# Altium schematic sheet MPD_MINI_DEMO_B2.SchDoc(MPD_MINI_DEMO_B2)
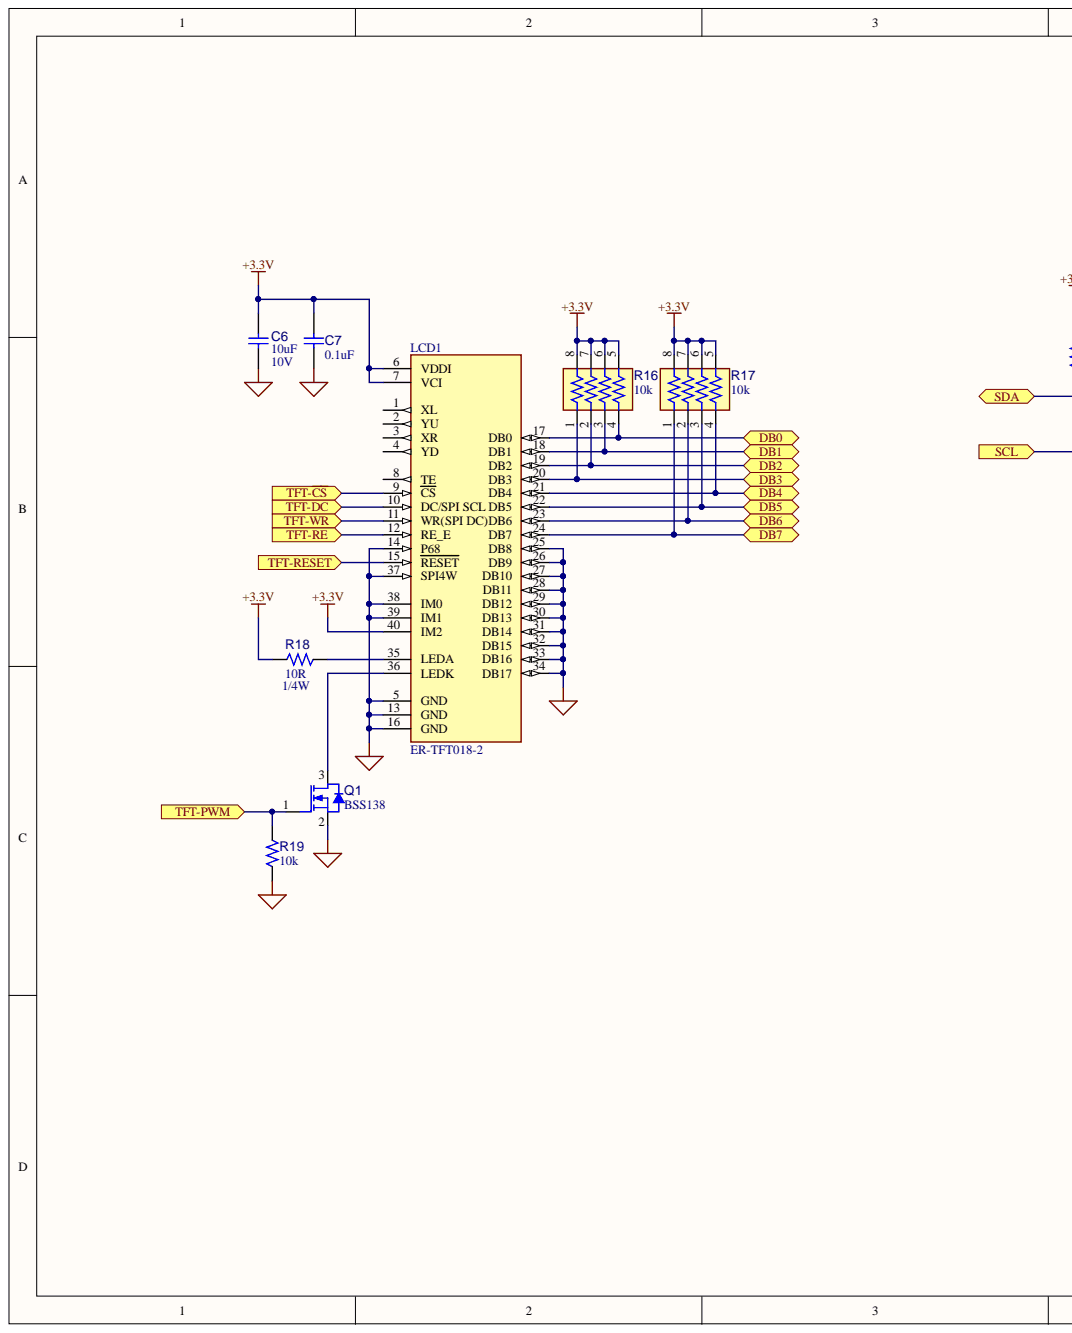
[diagram p0: MPD_MINI_DEMO_B2.SchDoc, left]
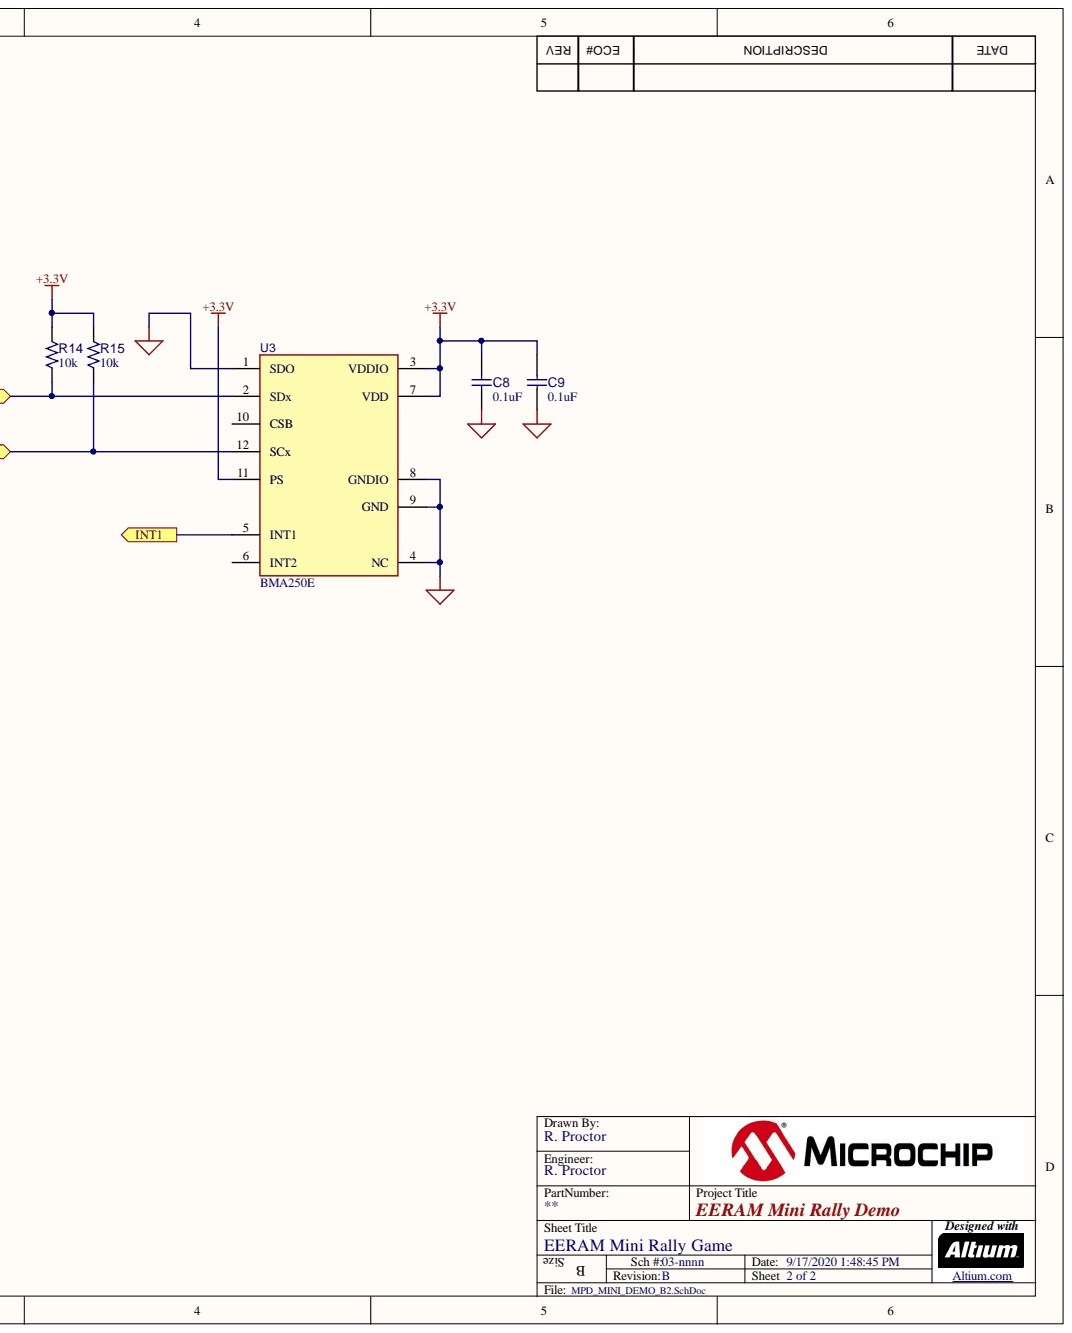
[diagram p1: MPD_MINI_DEMO_B2.SchDoc, right]

## Parts
C6  value 10uF  Voltage: 10V  [CAP_C_0603]  (hidden comment: Capacitor NP)
C7  value 0.1uF  [CAP_C_0603]  (hidden comment: Capacitor NP)
C8  value 0.1uF  [CAP_C_0603]  (hidden comment: Capacitor NP)
C9  value 0.1uF  [CAP_C_0603]  (hidden comment: Capacitor NP)
LCD1  value ER-TFT018-2  [DISPLAY_LCD_ER-TFT018-2]  (hidden comment: DISPLAY LCD ER-TFT018-2)
Q1  value BSS138  [SOT-23-3]  (hidden comment: Transistor MOS-N GSD)
R14  value 10k  [RES_C_0603]  (hidden comment: Resistor)
R15  value 10k  [RES_C_0603]  (hidden comment: Resistor)
R16  value 10k  [RES_C_CAV_0402X4]  (hidden comment: Resistor Network 4-Ch)
R17  value 10k  [RES_C_CAV_0402X4]  (hidden comment: Resistor Network 4-Ch)
R18  value 10R  Power: 1/4W  [RES_C_1206]  (hidden comment: Resistor)
R19  value 10k  [RES_C_0603]  (hidden comment: Resistor)
U3  BMA250E  [LGA-12_2x2]

## Ports
DB0, DB1, DB2, DB3, DB4, DB5, DB6, DB7, INT1, SCL, SDA, TFT-DC, TFT-PWM, TFT-RE, TFT-RESET, TFT-WR, TFT-~{CS}

## Nets
+3.3V: C6.2 C7.1 C8.1 C9.1 LCD1.6(VDDI) LCD1.7(VCI) LCD1.40(IM2) R14.1 R15.1 R16.5 R16.6 R16.7 R16.8 R17.5 R17.6 R17.7 R17.8 R18.1 U3.3(VDDIO) U3.7(VDD) U3.11(PS)
GND: C6.1 C7.2 C8.2 C9.2 LCD1.5(GND) LCD1.13(GND) LCD1.14(P68) LCD1.16(GND) LCD1.25(DB8) LCD1.26(DB9) LCD1.27(DB10) LCD1.28(DB11) LCD1.29(DB12) LCD1.30(DB13) LCD1.31(DB14) LCD1.32(DB15) LCD1.33(DB16) LCD1.34(DB17) LCD1.37(SPI4W) LCD1.38(IM0) LCD1.39(IM1) Q1.2 R19.2 U3.1(SDO) U3.4(NC) U3.8(GNDIO) U3.9(GND)
DB0: LCD1.17(DB0) R16.4 ; port DB0
DB1: LCD1.18(DB1) R16.3 ; port DB1
DB2: LCD1.19(DB2) R16.2 ; port DB2
DB3: LCD1.20(DB3) R16.1 ; port DB3
DB4: LCD1.21(DB4) R17.4 ; port DB4
DB5: LCD1.22(SCL_DB5) R17.3 ; port DB5
DB6: LCD1.23(DC)_DB6) R17.2 ; port DB6
DB7: LCD1.24(DB7) R17.1 ; port DB7
INT1: U3.5(INT1) ; port INT1
SCL: R15.2 U3.12(SCx) ; port SCL
SDA: R14.2 U3.2(SDx) ; port SDA
TFT-~{CS}: LCD1.9(CS) ; port TFT-~{CS}
TFT-DC: LCD1.10 ; port TFT-DC
TFT-PWM: Q1.1 R19.1 ; port TFT-PWM
TFT-RE: LCD1.12(RE_E) ; port TFT-RE
TFT-RESET: LCD1.15(RESET) ; port TFT-RESET
TFT-WR: LCD1.11 ; port TFT-WR
NetLCD1_35: LCD1.35(LEDA) R18.2
NetLCD1_36: LCD1.36(LEDK) Q1.3

Not connected: LCD1 1(XL) 2(YU) 3(XR) 4(YD) 8(TE); U3 6(INT2) 10(CSB)

## Library data of the parts
C6 = CAP0542: Capacitor; Manufacturer Part Number 1: ECJ-1VB1A106M; Manufacturer 1: Panasonic; Type: Ceramic; Tolerance: 20%; Temperature Coeffcient: X5R; Populated: Yes; Dev Tools Status: Production; Description_: CAP CER 10uF 10V 20% X5R SMD 0603; Symbol: Capacitor NP; Supplier Part Number 2: 445-6853-1-ND; Supplier Part Number 1: PCC2479CT-ND; Supplier 2: Digi-Key; Supplier 1: Digi-Key; Status: MCL Design; Revision: 2; Quantity Override: 1; Package: 0603; Operating Temperature: -55C to +85C; MCHP-ID: CAP0542; Manufacturer Part Number 2: C1608X5R1A106M; Manufacturer 2: TDK Corporation; Library Name: MCHP-CDB.DbLib; Database Table Name: Capacitor; ComponentLink1URL: https://chn-vm-apps.microchip.com/mchprepo/parts/Datasheets/ECJ__General_.pdf; ComponentLink1Description: Datasheet; Component Kind: Standard; Category: Capacitor
C7-C9 = CAP1047: Capacitor; Manufacturer Part Number 1: C1608X7R1H104M; Manufacturer 1: TDK; Voltage: 50V; Type: Ceramic; Tolerance: 20%; Temperature Coeffcient: X7R; Populated: Yes; Owner: Leah Whitaker; Dev Tools Status: Production; Description_: CAP CER 0.1uF 50V 20% X7R SMD 0603; Symbol: Capacitor NP; Supplier Part Number 2: C1608X7R1H104M080AA; Supplier Part Number 1: 445-5098-1-ND; Supplier 2: TDK; Supplier 1: Digi-Key; Status: MCL Design; Revision: 1; Quantity Override: 1; Package: 0603; Operating Temperature: -55C to +125C; MCHP-ID: CAP1047; Manufacturer Part Number 2: C1608X7R1H104M080AA; Manufacturer 2: TDK; Library Name: MCHP-CDB.DbLib; Database Table Name: Capacitor; ComponentLink1URL: https://chn-vm-apps.microchip.com/mchprepo/parts/Datasheets/general_B11.pdf; ComponentLink1Description: Datasheet; Component Kind: Standard; Category: Capacitor
LCD1 = DISPLAY0014: Manufacturer 1: EastRising Technology Co., Limited; Populated: YES; Owner: Mark Loper; Dev Tools Status: Design; Description_: DISPLAY LCD TFT 128x160 GRAPHIC ILI9163C ER-TFT018-2; Type: LCD; Symbol: DISPLAY LCD ER-TFT018-2; Supplier Part Number 1: ER-TFT018-2; Supplier 1: BUYDISPLAY.COM; Status: MCL Design; Revision: A; Quantity Override: 1; MCHP-ID: DISPLAY0014; Manufacturer Part Number 1: ER-TFT018-2; Library Name: MCHP-CDB.DbLib; Database Table Name: Display; ComponentLink1URL: https://chn-vm-apps.microchip.com/mchprepo/parts/Datasheets/ER-TFT0018-2_Datasheet.pdf; ComponentLink1Description: Datasheet; Component Kind: Standard; Category: Display
Q1 = TRA1102: Transistor MOS; Manufacturer Part Number 1: 2N7002-7-F; Manufacturer 1: Diodes Inc; Type: FET; Power: 370mW; Populated: YES; Owner: Adrian M (M91404); Gate to Source Voltage: 20V; Drain to Source Voltage: 60V; Dev Tools Status: Production; Description_: TRANS FET N-CH 2N7002-7-F 60V 170mA 370mW SOT-23-3; Current: 170mA; Symbol: Transistor MOS-N GSD; Supplier Part Number 1: 2N7002-FDICT-ND; Supplier 1: Digi-Key; Status: MCL Design; Revision: 0; Quantity Override: 1; Package: SOT-23-3; Operating Temperature: -55C to +150C; MCHP-ID: TRA1102; Library Name: MCHP-CDB.DbLib; Database Table Name: Transistor; ComponentLink1URL: https://chn-vm-apps.microchip.com/mchprepo/parts/Datasheets/ds11303.pdf; ComponentLink1Description: Datasheet; Component Kind: Standard; Category: Transistor
R14 R15 R19 = RSMT0139: Manufacturer Part Number 1: ERJ-3EKF1002V; Manufacturer 1: Panasonic; Tolerance: 1%; Temperature Coeffcient: 100ppm/C; Power: 1/10W; Populated: Yes; Dev Tools Status: Production; Description_: RES TKF 10k 1% 1/10W SMD 0603; Type: RES SMD; Symbol: Resistor; Supplier Part Number 6: NRC06F1002TRF; Supplier Part Number 5: 302-10k-RC; Supplier Part Number 4: RMCF0603FT10K0TR-ND; Supplier Part Number 3: 311-10.0KHRCT-ND; Supplier Part Number 2: RMCF1/1610KFRTR-ND; Supplier Part Number 1: P10.0KHCT-ND; Supplier 6: NIC Components; Supplier 5: Mouser; Supplier 4: Digi-Key; Supplier 3: Digi-Key; Supplier 2: Digi-Key; Supplier 1: Digi-Key; Status: MCL Design; Revision: 2; Quantity Override: 1; Package: 0603; Operating Temperature: -55C to +155C; MCHP-ID: RSMT0139; Manufacturer Part Number 6: NRC06F1002TRF; Manufacturer Part Number 5: 302-10K-RC; Manufacturer Part Number 4: RMCF 1/16 10K 1% R; Manufacturer Part Number 3: RC0603FR-0710KL; Manufacturer Part Number 2: RMCF0603FT10K0; Manufacturer 6: NIC Components; Manufacturer 5: Xicon; Manufacturer 4: Stackpole Electronics Inc; Manufacturer 3: Yageo; Manufacturer 2: Stackpole Electronics Inc; Library Name: MCHP-CDB.DbLib; Description: Resistor; Database Table Name: Resistor; Composition: TKF; ComponentLink1URL: https://chn-vm-apps.microchip.com/mchprepo/parts/Datasheets/nrc.pdf; ComponentLink1Description: Datasheet; Component Kind: Standard; Category: Resistor
R16 R17 = RES1389: Resistor; Manufacturer Part Number 1: EXB-N8V103JX; Manufacturer 1: Panasonic Electronic Components; Voltage: 50V; Tolerance: 5%; Temperature Coeffcient: 200ppm/C; Power: 31mW; Populated: YES; Owner: Jairuz Agang-ang; Dev Tools Status: Production; Description_: RES NET TKF 10k 5% 31mW SMD 0402x4; Circuit Type: Isolated; Type: RES Network SMD; Symbol: Resistor Network 4-Ch; Supplier Part Number 1: Y10103TR-ND; Supplier 1: Digi-Key; Status: MCL Design; Revision: 4; Quantity Override: 1; Package: 0804; Operating Temperature: -55C to +125C; MCHP-ID: RES1389; Library Name: MCHP-CDB.DbLib; Database Table Name: Resistor; Composition: TKF; ComponentLink1URL: https://chn-vm-apps.microchip.com/mchprepo/parts/Datasheets/AOC0000CE1.pdf; ComponentLink1Description: Datasheet; Component Kind: Standard; Category: Resistor
R18 = RES1393: Manufacturer Part Number 1: ERJ-8ENF10R0V; Manufacturer 1: Panasonic Electronic Components; Voltage: 200V; Tolerance: 1%; Temperature Coeffcient: 100ppm/C; Populated: YES; Owner: Jairuz Agang-ang; Dev Tools Status: Production; Description_: RES TKF 10R 1% 1/4W SMD 1206; Circuit Type: Isolated; Type: RES SMD; Symbol: Resistor; Supplier Part Number 1: P10.0FCT-ND; Supplier 1: Digi-Key; Status: MCL Design; Revision: 1; Quantity Override: 1; Package: 1206; Operating Temperature: -55C to +155C; MCHP-ID: RES1393; Library Name: MCHP-CDB.DbLib; Description: Resistor; Database Table Name: Resistor; Composition: TKF; ComponentLink1URL: https://chn-vm-apps.microchip.com/mchprepo/parts/Datasheets/AOA0000CE2.pdf; ComponentLink1Description: Datasheet; Component Kind: Standard; Category: Resistor
U3 = SNSR1007: BMA250E LGA-12; Manufacturer 1: Bosch Sensortec; Populated: YES; Measured Unit: g; Dev Tools Status: Production; Description_: SENSOR ACCEL BMA250E SMD LGA-12; Database Table Name: Sensor; Value: BMA250E; Type: Accelerometer; Symbol: BMA250E LGA-12; Supplier Part Number 1: 828-1040-1-ND; Supplier 1: Digi-Key; Status: MCL Design; Revision: A; Quantity Override: 1; Package: LGA-12_2x2; MCHP-ID: SNSR1007; Manufacturer Part Number 1: BMA250E; Library Name: MCHP-CDB.DbLib; ComponentLink1URL: https://chn-vm-apps.microchip.com/mchprepo/parts/Datasheets/BSTBMA250EDS00403_published.pdf; ComponentLink1Description: Datasheet; Component Kind: Standard; Category: Sensor
